# Altium schematic sheet controleSTM.SchDoc(controleSTM)
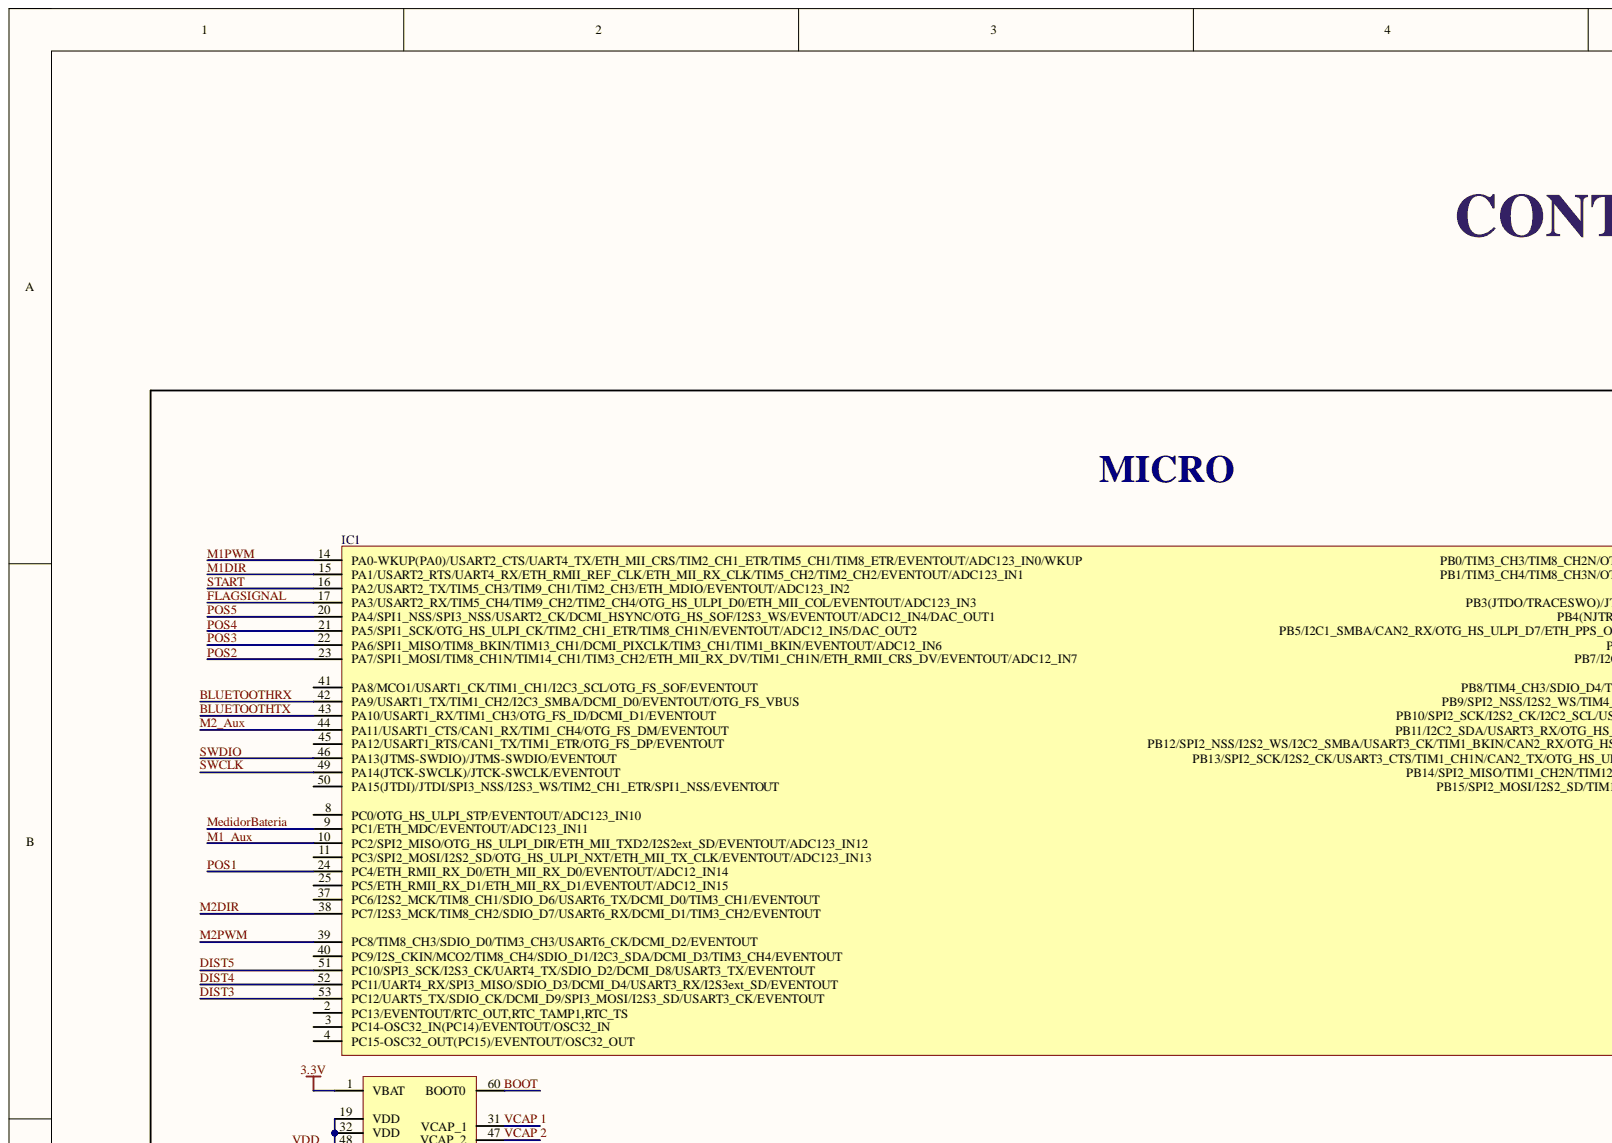
[diagram p0: controleSTM.SchDoc, top left]
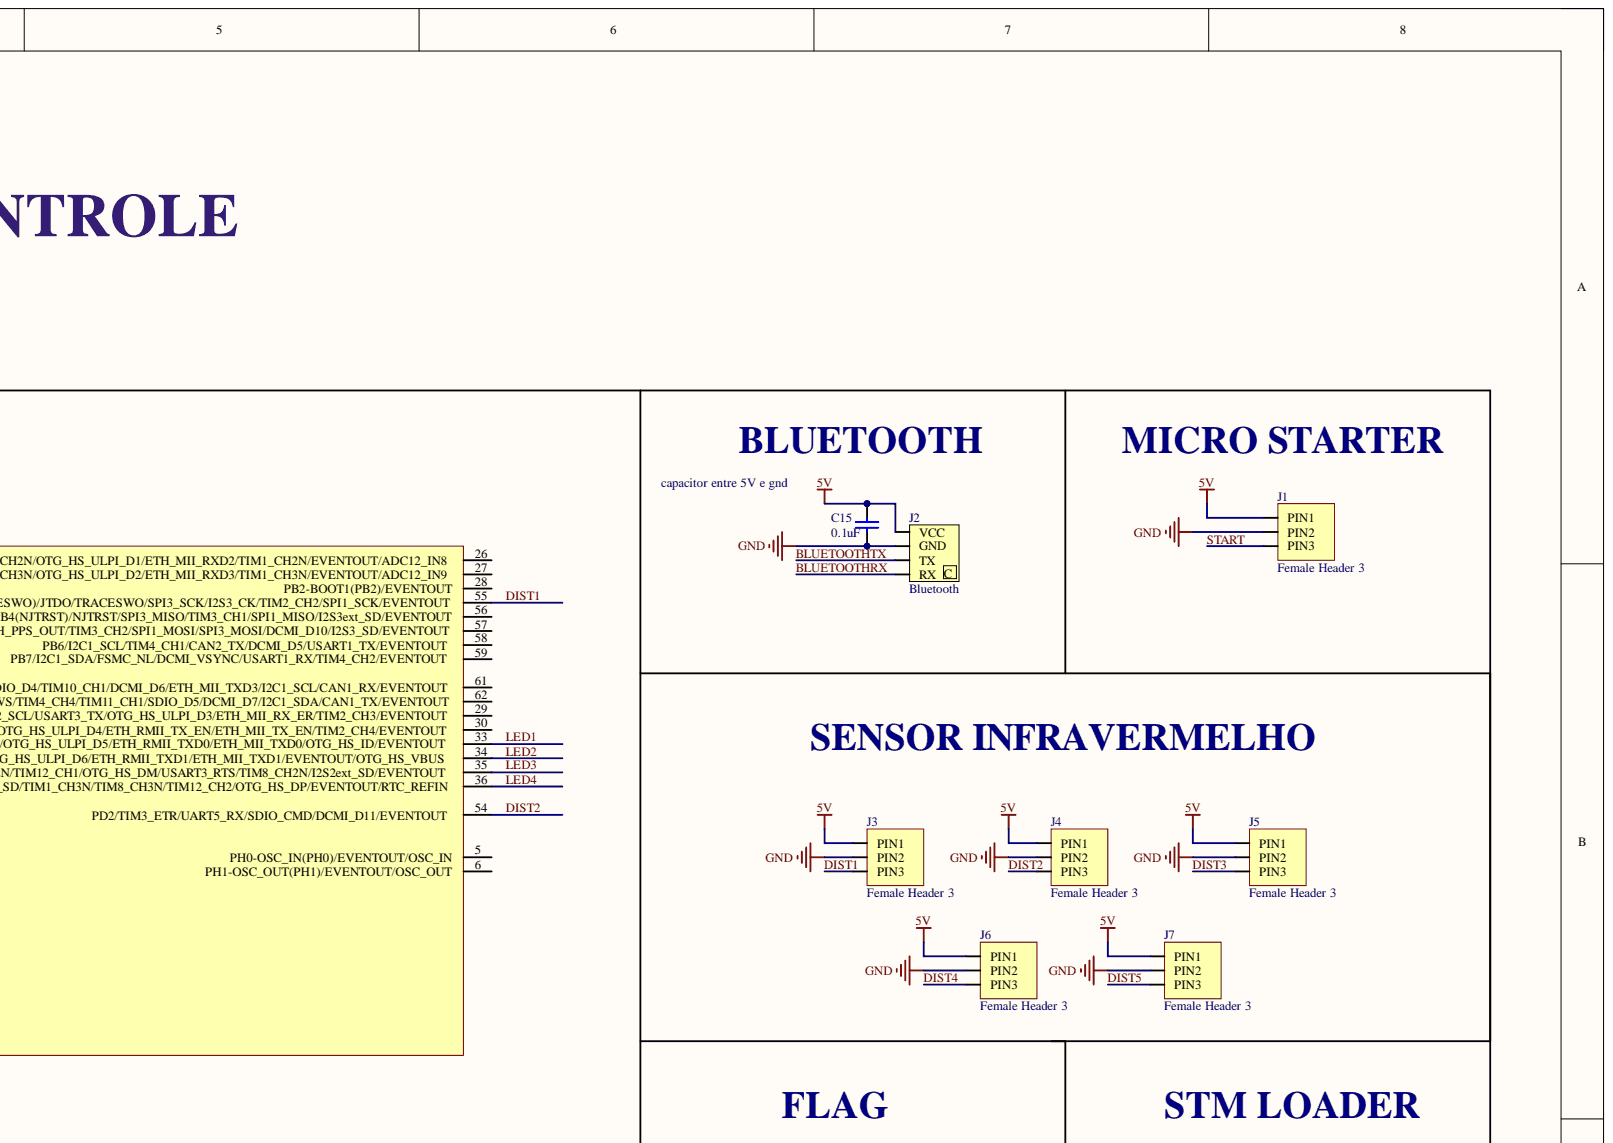
[diagram p1: controleSTM.SchDoc, top right]
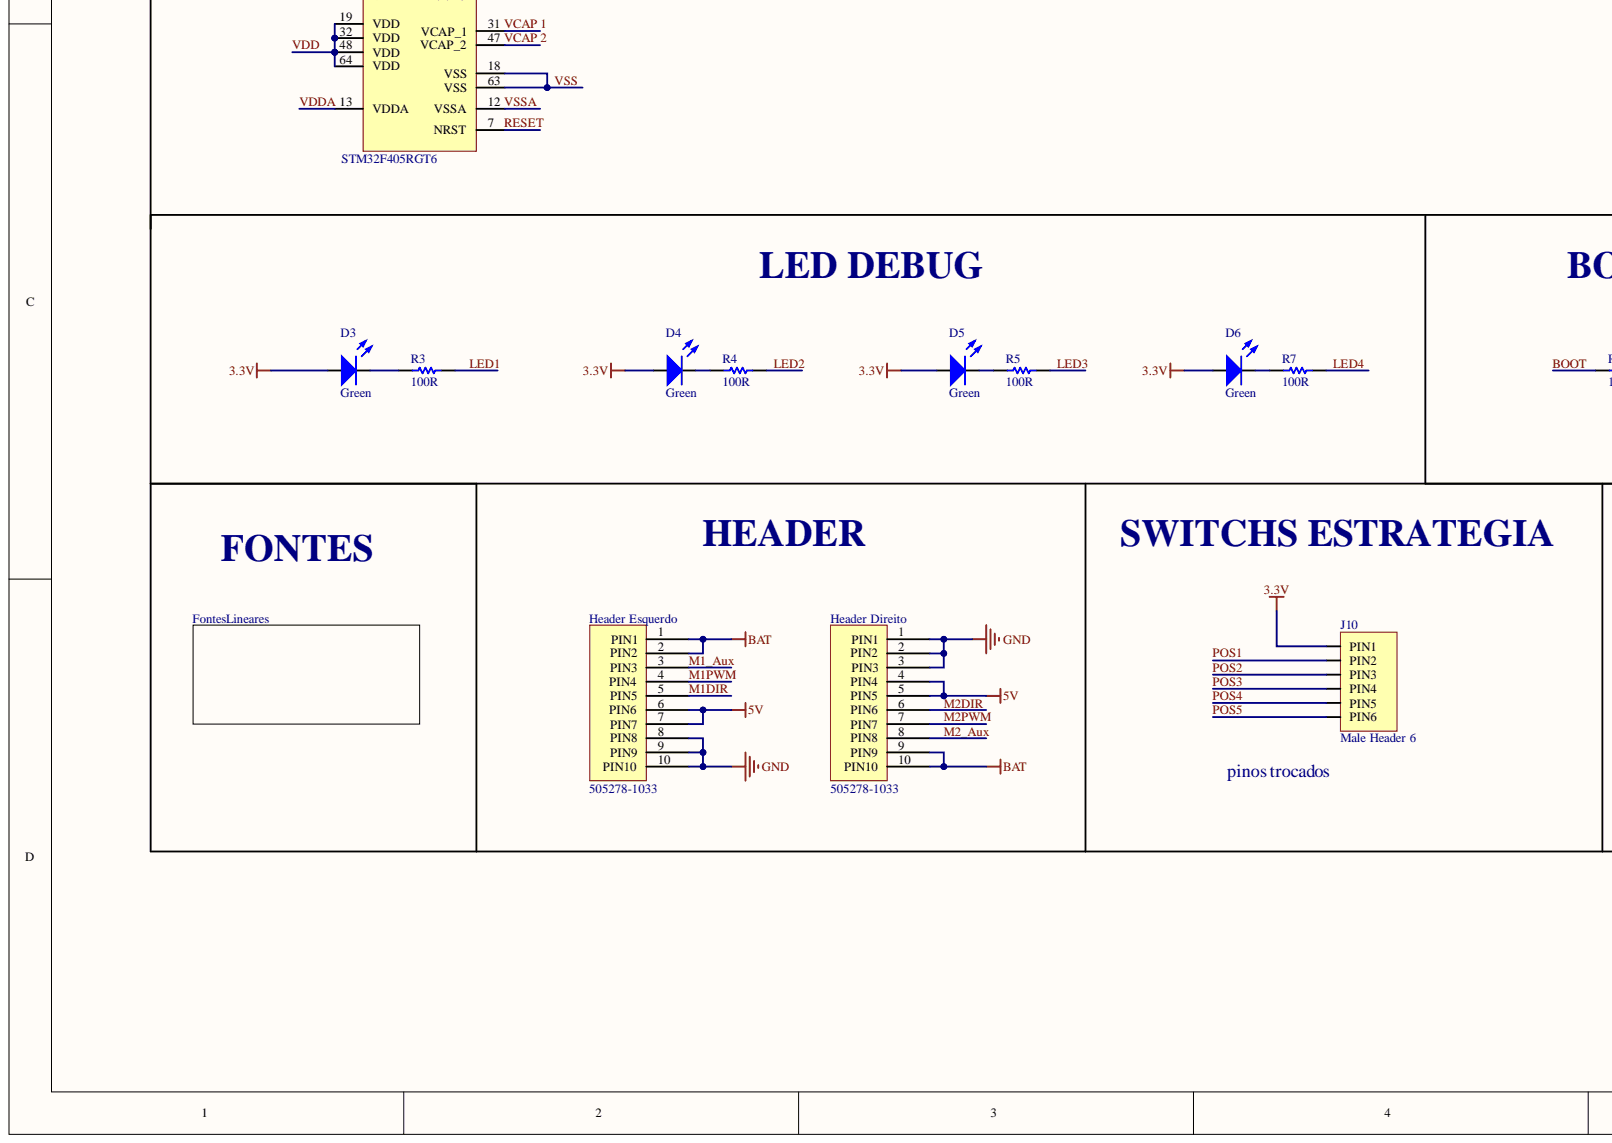
[diagram p2: controleSTM.SchDoc, bottom left]
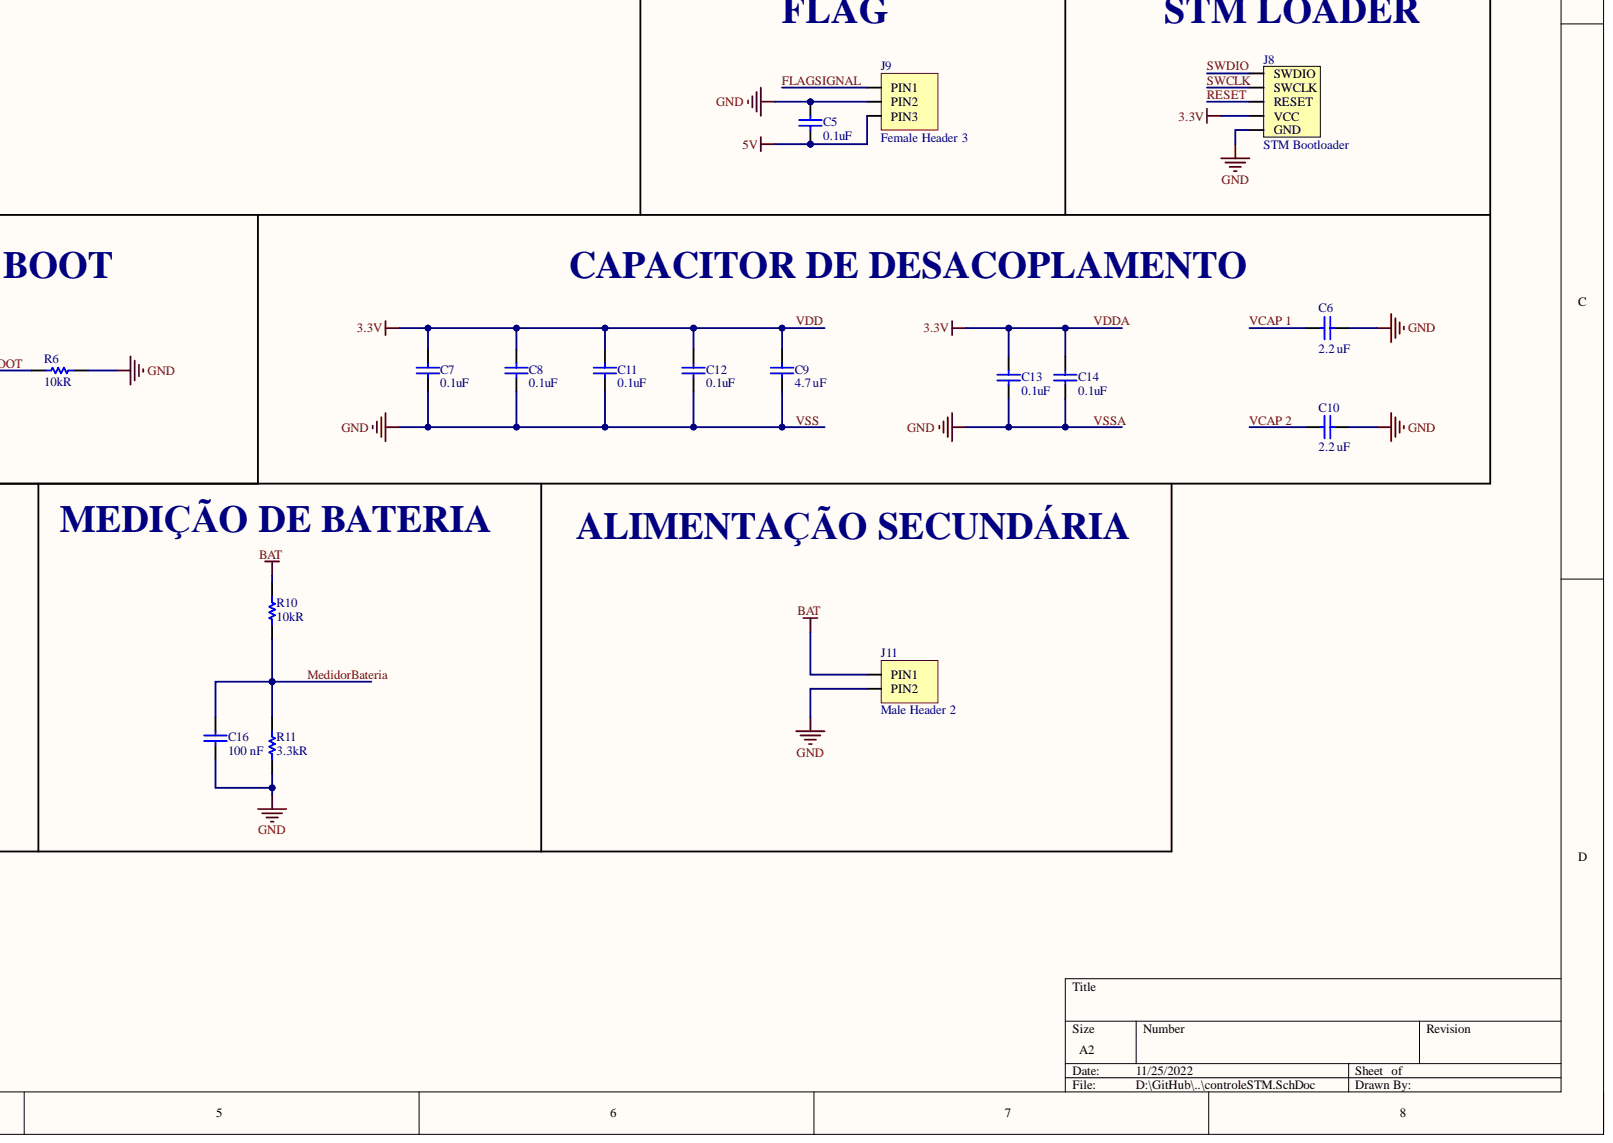
[diagram p3: controleSTM.SchDoc, bottom right]

## Parts
C5  0.1uF  [C0805]
C6  2.2 uF  [C0805]
C7  0.1uF  [C0805]
C8  0.1uF  [C0805]
C9  4.7 uF  [C0805]
C10  2.2 uF  [C0805]
C11  0.1uF  [C0805]
C12  0.1uF  [C0805]
C13  0.1uF  [C0805]
C14  0.1uF  [C0805]
C15  0.1uF  [C0805]
C16  100 nF  [C0805]
D3  Green  [LED_0603]
D4  Green  [LED_0603]
D5  Green  [LED_0603]
D6  Green  [LED_0603]
Header_Direito  505278-1033  [FPC_CON]
Header_Esquerdo  505278-1033  [FPC_CON]
IC1  STM32F405RGT6  [LQFP-64]
J1  Female Header 3  Library Ref: Header  [FEMALE HEADER 3]
J2  Bluetooth  [BLUETOOTH]
J3  Female Header 3  Library Ref: Header  [FEMALE HEADER 3]
J4  Female Header 3  Library Ref: Header  [FEMALE HEADER 3]
J5  Female Header 3  Library Ref: Header  [FEMALE HEADER 3]
J6  Female Header 3  Library Ref: Header  [FEMALE HEADER 3]
J7  Female Header 3  Library Ref: Header  [FEMALE HEADER 3]
J8  STM Bootloader  [STM BOOTLOADER]
J9  Female Header 3  Library Ref: Header  [FEMALE HEADER 3]
J10  Male Header 6  Library Ref: Header  [MALE HEADER 6]
J11  Male Header 2  Library Ref: Header  [MALE HEADER 2]
R3  100R  [RESISTOR_0805]
R4  100R  [RESISTOR_0805]
R5  100R  [RESISTOR_0805]
R6  10kR  [RESISTOR_0603]
R7  100R  [RESISTOR_0805]
R10  10kR  [RESISTOR_0603]
R11  3.3kR  [RESISTOR_0603]

## Nets
5V: C5.1 C15.2 Header_Direito.4(PIN4) Header_Direito.5(PIN5) Header_Esquerdo.6(PIN6) Header_Esquerdo.7(PIN7) J1.PIN1 J2.VCC J3.PIN1 J4.PIN1 J5.PIN1 J6.PIN1 J7.PIN1 J9.PIN3
BAT: Header_Direito.9(PIN9) Header_Direito.10(PIN10) Header_Esquerdo.1(PIN1) Header_Esquerdo.2(PIN2) J11.PIN1 R10.2
BLUETOOTHRX: IC1.42(PA9/USART1_TX/TIM1_CH2/I2C3_SMBA/DCMI_D0/EVENTOUT/OTG_FS_VBUS) J2.RX
BLUETOOTHTX: IC1.43(PA10/USART1_RX/TIM1_CH3/OTG_FS_ID/DCMI_D1/EVENTOUT) J2.TX
BOOT: IC1.60 R6.1
DIST1: IC1.55(PB3(JTDO/TRACESWO)/JTDO/TRACESWO/SPI3_SCK/I2S3_CK/TIM2_CH2/SPI1_SCK/EVENTOUT) J3.PIN3
DIST2: IC1.54(PD2/TIM3_ETR/UART5_RX/SDIO_CMD/DCMI_D11/EVENTOUT) J4.PIN3
DIST3: IC1.53(PC12/UART5_TX/SDIO_CK/DCMI_D9/SPI3_MOSI/I2S3_SD/USART3_CK/EVENTOUT) J5.PIN3
DIST4: IC1.52(PC11/UART4_RX/SPI3_MISO/SDIO_D3/DCMI_D4/USART3_RX/I2S3ext_SD/EVENTOUT) J6.PIN3
DIST5: IC1.51(PC10/SPI3_SCK/I2S3_CK/UART4_TX/SDIO_D2/DCMI_D8/USART3_TX/EVENTOUT) J7.PIN3
FLAGSIGNAL: IC1.17(PA3/USART2_RX/TIM5_CH4/TIM9_CH2/TIM2_CH4/OTG_HS_ULPI_D0/ETH_MII_COL/EVENTOUT/ADC123_IN3) J9.PIN1
LED1: IC1.33(PB12/SPI2_NSS/I2S2_WS/I2C2_SMBA/USART3_CK/TIM1_BKIN/CAN2_RX/OTG_HS_ULPI_D5/ETH_RMII_TXD0/ETH_MII_TXD0/OTG_HS_ID/EVENTOUT) R3.2
LED2: IC1.34(PB13/SPI2_SCK/I2S2_CK/USART3_CTS/TIM1_CH1N/CAN2_TX/OTG_HS_ULPI_D6/ETH_RMII_TXD1/ETH_MII_TXD1/EVENTOUT/OTG_HS_VBUS) R4.2
LED3: IC1.35(PB14/SPI2_MISO/TIM1_CH2N/TIM12_CH1/OTG_HS_DM/USART3_RTS/TIM8_CH2N/I2S2ext_SD/EVENTOUT) R5.2
LED4: IC1.36(PB15/SPI2_MOSI/I2S2_SD/TIM1_CH3N/TIM8_CH3N/TIM12_CH2/OTG_HS_DP/EVENTOUT/RTC_REFIN) R7.2
M1_Aux: Header_Esquerdo.3(PIN3) IC1.10(PC2/SPI2_MISO/OTG_HS_ULPI_DIR/ETH_MII_TXD2/I2S2ext_SD/EVENTOUT/ADC123_IN12)
M1DIR: Header_Esquerdo.5(PIN5) IC1.15(PA1/USART2_RTS/UART4_RX/ETH_RMII_REF_CLK/ETH_MII_RX_CLK/TIM5_CH2/TIM2_CH2/EVENTOUT/ADC123_IN1)
M1PWM: Header_Esquerdo.4(PIN4) IC1.14(PA0-WKUP(PA0)/USART2_CTS/UART4_TX/ETH_MII_CRS/TIM2_CH1_ETR/TIM5_CH1/TIM8_ETR/EVENTOUT/ADC123_IN0/WKUP)
M2_Aux: Header_Direito.8(PIN8) IC1.44(PA11/USART1_CTS/CAN1_RX/TIM1_CH4/OTG_FS_DM/EVENTOUT)
M2DIR: Header_Direito.6(PIN6) IC1.38(PC7/I2S3_MCK/TIM8_CH2/SDIO_D7/USART6_RX/DCMI_D1/TIM3_CH2/EVENTOUT)
M2PWM: Header_Direito.7(PIN7) IC1.39(PC8/TIM8_CH3/SDIO_D0/TIM3_CH3/USART6_CK/DCMI_D2/EVENTOUT)
MedidorBateria: C16.2 IC1.9(PC1/ETH_MDC/EVENTOUT/ADC123_IN11) R10.1 R11.2
POS1: IC1.24(PC4/ETH_RMII_RX_D0/ETH_MII_RX_D0/EVENTOUT/ADC12_IN14) J10.PIN2
POS2: IC1.23(PA7/SPI1_MOSI/TIM8_CH1N/TIM14_CH1/TIM3_CH2/ETH_MII_RX_DV/TIM1_CH1N/ETH_RMII_CRS_DV/EVENTOUT/ADC12_IN7) J10.PIN3
POS3: IC1.22(PA6/SPI1_MISO/TIM8_BKIN/TIM13_CH1/DCMI_PIXCLK/TIM3_CH1/TIM1_BKIN/EVENTOUT/ADC12_IN6) J10.PIN4
POS4: IC1.21(PA5/SPI1_SCK/OTG_HS_ULPI_CK/TIM2_CH1_ETR/TIM8_CH1N/EVENTOUT/ADC12_IN5/DAC_OUT2) J10.PIN5
POS5: IC1.20(PA4/SPI1_NSS/SPI3_NSS/USART2_CK/DCMI_HSYNC/OTG_HS_SOF/I2S3_WS/EVENTOUT/ADC12_IN4/DAC_OUT1) J10.PIN6
RESET: IC1.7 J8.RESET
START: IC1.16(PA2/USART2_TX/TIM5_CH3/TIM9_CH1/TIM2_CH3/ETH_MDIO/EVENTOUT/ADC123_IN2) J1.PIN3
SWCLK: IC1.49(PA14(JTCK-SWCLK)/JTCK-SWCLK/EVENTOUT) J8.CLK(SWCLK)
SWDIO: IC1.46(PA13(JTMS-SWDIO)/JTMS-SWDIO/EVENTOUT) J8.DIO(SWDIO)
VCAP 1: C6.1 IC1.31
VCAP 2: C10.1 IC1.47
VDD: C7.2 C8.2 C9.2 C11.2 C12.2 C13.2 C14.2 D3.A D4.A D5.A D6.A IC1.1(VBAT) IC1.13(VDDA) IC1.19(VDD) IC1.32(VDD) IC1.48(VDD) IC1.64(VDD) J8.VCC J10.PIN1
VSS: C5.2 C6.2 C7.1 C8.1 C9.1 C10.2 C11.1 C12.1 C13.1 C14.1 C15.1 C16.1 Header_Direito.1(PIN1) Header_Direito.2(PIN2) Header_Direito.3(PIN3) Header_Esquerdo.8(PIN8) Header_Esquerdo.9(PIN9) Header_Esquerdo.10(PIN10) IC1.12 IC1.18 IC1.63 J1.PIN2 J2.GND J3.PIN2 J4.PIN2 J5.PIN2 J6.PIN2 J7.PIN2 J8.GND J9.PIN2 J11.PIN2 R6.2 R11.1
NetD3_C: D3.C R3.1
NetD4_C: D4.C R4.1
NetD5_C: D5.C R5.1
NetD6_C: D6.C R7.1

Not connected: IC1 2(PC13/EVENTOUT/RTC_OUT,RTC_TAMP1,RTC_TS) 3(PC14-OSC32_IN(PC14)/EVENTOUT/OSC32_IN) 4(PC15-OSC32_OUT(PC15)/EVENTOUT/OSC32_OUT) 5(PH0-OSC_IN(PH0)/EVENTOUT/OSC_IN) 6(PH1-OSC_OUT(PH1)/EVENTOUT/OSC_OUT) 8(PC0/OTG_HS_ULPI_STP/EVENTOUT/ADC123_IN10) 11(PC3/SPI2_MOSI/I2S2_SD/OTG_HS_ULPI_NXT/ETH_MII_TX_CLK/EVENTOUT/ADC123_IN13) 25(PC5/ETH_RMII_RX_D1/ETH_MII_RX_D1/EVENTOUT/ADC12_IN15) 26(PB0/TIM3_CH3/TIM8_CH2N/OTG_HS_ULPI_D1/ETH_MII_RXD2/TIM1_CH2N/EVENTOUT/ADC12_IN8) 27(PB1/TIM3_CH4/TIM8_CH3N/OTG_HS_ULPI_D2/ETH_MII_RXD3/TIM1_CH3N/EVENTOUT/ADC12_IN9) 28(PB2-BOOT1(PB2)/EVENTOUT) 29(PB10/SPI2_SCK/I2S2_CK/I2C2_SCL/USART3_TX/OTG_HS_ULPI_D3/ETH_MII_RX_ER/TIM2_CH3/EVENTOUT) 30(PB11/I2C2_SDA/USART3_RX/OTG_HS_ULPI_D4/ETH_RMII_TX_EN/ETH_MII_TX_EN/TIM2_CH4/EVENTOUT) 37(PC6/I2S2_MCK/TIM8_CH1/SDIO_D6/USART6_TX/DCMI_D0/TIM3_CH1/EVENTOUT) 40(PC9/I2S_CKIN/MCO2/TIM8_CH4/SDIO_D1/I2C3_SDA/DCMI_D3/TIM3_CH4/EVENTOUT) 41(PA8/MCO1/USART1_CK/TIM1_CH1/I2C3_SCL/OTG_FS_SOF/EVENTOUT) 45(PA12/USART1_RTS/CAN1_TX/TIM1_ETR/OTG_FS_DP/EVENTOUT) 50(PA15(JTDI)/JTDI/SPI3_NSS/I2S3_WS/TIM2_CH1_ETR/SPI1_NSS/EVENTOUT) 56(PB4(NJTRST)/NJTRST/SPI3_MISO/TIM3_CH1/SPI1_MISO/I2S3ext_SD/EVENTOUT) 57(PB5/I2C1_SMBA/CAN2_RX/OTG_HS_ULPI_D7/ETH_PPS_OUT/TIM3_CH2/SPI1_MOSI/SPI3_MOSI/DCMI_D10/I2S3_SD/EVENTOUT) 58(PB6/I2C1_SCL/TIM4_CH1/CAN2_TX/DCMI_D5/USART1_TX/EVENTOUT) 59(PB7/I2C1_SDA/FSMC_NL/DCMI_VSYNC/USART1_RX/TIM4_CH2/EVENTOUT) 61(PB8/TIM4_CH3/SDIO_D4/TIM10_CH1/DCMI_D6/ETH_MII_TXD3/I2C1_SCL/CAN1_RX/EVENTOUT) 62(PB9/SPI2_NSS/I2S2_WS/TIM4_CH4/TIM11_CH1/SDIO_D5/DCMI_D7/I2C1_SDA/CAN1_TX/EVENTOUT)

## Library data of the parts
C5 C7 C8 C11-C15 = C0805C104M3RACTU: CAP CER 0.1uF 25V X7R 0805; Manufacturer: Kemet; Library Control - Checked Footprint: No; Library Control - Checked Schematic: Yes; Library Control - Person that Checked Schematic: Guilherme; Library Control - Person that did the Last Update Schematic: Marcos; Tolerance: 20%; Voltage - Rated: 25 VDC; Category: Ceramic Capacitor; Component Kind: Standard; ComponentLink1Description: Datasheet; ComponentLink1URL: https://br.mouser.com/datasheet/2/212/KEM_C1002_X7R_SMD-1102033.pdf; ComponentLink2Description: Mouser Link; ComponentLink2URL: https://br.mouser.com/ProductDetail/KEMET/C0805C104M3RACTU?qs=jbCUrP3sAgSIdMuvv9W4Pg%3D%3D; Dieletric: X7R; ESR: -; Library Control - Last Update: 26/06/2021 20:52; Library Ref: Capacitor; Operating Temperature: -55 C ~ 125 C; Package / Case: 0805(2012 mestric); Part Number: C0805C104M3RACTU; Price: 1=0,13 10=0,064 100=0,037 1000=0,025 (USD); Size / Dimension: 1.25 mm W x 2 mm L x 0.78 mm H; Supplier 1: Mouser; Supplier Part Number 1: 80-C0805C104M3R; Value: 0.1uF; Library Name: Uai!Tecas.IntLib
C6 C10 = GCM21BR71C225KA64L: CAP CER 2.2uF 16V X7R 0805; Manufacturer: MURATA ELECTRONICS; Library Control - Checked Footprint: Yes; Library Control - Person that Checked Footprint: Cage; Tolerance: 10%; Voltage - Rated: 16 VDC; Category: Ceramic capacitor; Component Kind: Standard; ComponentLink1Description: Datasheet; ComponentLink1URL: https://br.mouser.com/ProductDetail/Murata-Electronics/GCM21BR71C225KA64L?qs=aEuGZpxfbxV0E5XH%252BwYupA%3D%3D; ComponentLink2Description: Mouser Link; ComponentLink2URL: https://br.mouser.com/ProductDetail/Murata-Electronics/GCM21BR71C225KA64L?qs=aEuGZpxfbxV0E5XH%252BwYupA%3D%3D; Dieletric: X7R; ESR: -; Library Control - Checked Schematic: Yes; Library Control - Last Update: 25/08/22; Library Ref: Capacitor; Operating Temperature: -55°C ~125ºC; Package / Case: 0805(2012 Metric); Part Number: GCM21BR71C225KA64L; Price: 1=0,41 10=0,283 100=0,169 1000=0,107 (USD); Size / Dimension: 2 mm L  x 1.25 mm W x 1.25 mm H; Supplier 1: Mouser; Supplier Part Number 1: 81-GCM21BR71C225KA4L; Value: 2.2 uF; Library Control - Person that Checked Schematic: Cage; Library Control - Person that did the Last Update Footprint: Cage; Library Control - Person that did the Last Update Schematic: Cage; Library Name: Uai!Tecas.IntLib
C9 = GRM219R61E475KA73D: CAP CER 4.7uF 25V X5R 0805; Manufacturer: MURATA ELECTRONICS; Library Control - Checked Footprint: Yes; Library Control - Person that Checked Footprint: Cage; Tolerance: 10%; Voltage - Rated: 25 VDC; Category: Ceramic Capacitors; Component Kind: Standard; ComponentLink1Description: Datasheet; ComponentLink1URL: https://br.mouser.com/datasheet/2/281/1/GRM219R61E475KA73_01A-1986363.pdf; ComponentLink2Description: Mouser Link; ComponentLink2URL: https://br.mouser.com/ProductDetail/Murata-Electronics/GRM219R61E475KA73D?qs=%2Fha2pyFadui1540apPxqrcvNL2Od6%2FE9q78FE8iyERiL9ZO4NhnlONCgyc%2FC2rEQ; Dieletric: X5R; ESR: -; Library Control - Checked Schematic: Yes; Library Control - Last Update: 25/08/22; Library Ref: Capacitor; Operating Temperature: -55°C ~ 85°C; Package / Case: 0805(2012 metric); Part Number: GRM219R61E475KA73D; Price: 1=0,28 10=0,193 100=0,098 1000=0,059 (USD); Size / Dimension: 2 mm L x 1.25 mm W x 0.85 mm H; Supplier 1: Mouser; Supplier Part Number 1: 81-GRM219R61E475KA73D; Value: 4.7 uF; Library Control - Person that Checked Schematic: Cage; Library Control - Person that did the Last Update Footprint: Cage; Library Control - Person that did the Last Update Schematic: Cage; Library Name: Uai!Tecas.IntLib
C16 = 885012207128: CAP CER 100 nF 100V X7R 0805; Manufacturer: Wurth Elektronik; Library Control - Checked Footprint: Yes; Tolerance: 10%; Voltage - Rated: 100 VDC; Category: Ceramic capacitor; Component Kind: Standard; ComponentLink1Description: Datasheet; ComponentLink1URL: https://br.mouser.com/datasheet/2/445/885012207128-1727772.pdf; ComponentLink2Description: Mouser Link; ComponentLink2URL: https://br.mouser.com/ProductDetail/Wurth-Elektronik/885012207128?qs=MLItCLRbWsys1TnbPEYt%252BQ%3D%3D; Dieletric: X7R; ESR: -; Library Control - Checked Schematic: Yes; Library Ref: Capacitor; Operating Temperature: -55°C ~125ºC; Package / Case: 0805(2012 Metric); Part Number: 885012207128; Price: 1=0,41 10=0,283 100=0,169 1000=0,107 (USD); Size / Dimension: 2 mm L  x 1.25 mm W x 1.25 mm H; Supplier 1: Mouser; Supplier Part Number 1: 710-885012207128; Value: 100 nF; Library Name: Uai!Tecas.IntLib
D3-D6 = 150060VS75000: LED 72mW GREEN 40MCD 0603; Manufacturer: Wurth Elektronik; Current - Forward (If): 20 mA; Library Control - Last Project that Used: Diodo/Led; Library Control - Person that Checked Footprint: Stéfany (Ster); Library Control - Person that did the Last Update Schematic: Marcos; Max Current Rating: 100mA; Reverse Voltage: 5V; Viewing Angle: 140°; Voltage - Forward (Vf): 2 V; Wavelength: 570nm; Category: Standard LEDs - SMD; Color: Green; Component Kind: Standard; ComponentLink1Description: Mouser Link; ComponentLink1URL: https://br.mouser.com/ProductDetail/Wurth-Elektronik/150060VS75000?qs=%2Fha2pyFaduhuT%2FKGSijZP0vTyJZ9U993KfsBQSxqATYhY9jnc2c09tXoMoJ3wn4L; ComponentLink2Description: Datasheet Link; ComponentLink2URL: https://br.mouser.com/datasheet/2/445/150060VS75000-1714627.pdf; Library Control - Checked Footprint: 25/07/2021; Library Control - Checked Schematic: 25/07/2021; Library Control - Last Update: 09/09/2021 16:12; Library Ref: LED; Luminous Intensity: 40 mcd; Max Power Dissipation: 72mW; Operating Temperature: -40~85 °C; Package / Case: 0603; Part Number: 150060VS75000; Price: 1 = 0.14, 50 = 0.133, 100 = 0.114 (USD); Supplier 1: Mouser; Supplier Part Number 1: 710-150060VS75000; Library Control - Person that Checked Schematic: Stéfany (Ster); Library Control - Person that did the Last Update Footprint: Stéfany (Ster); Library Name: Uai!Tecas.IntLib
Header_Direito Header_Esquerdo = 505278-1033_BODY: CONN FFC/FPC HORZ 10POS 0.50MM; Manufacturer: Molex; Current Rating (Amps): 500mA; Library Control - Checked Footprint: Yes; Library Control - Last Project that Used: Follow-Final; Library Control - Person that Checked Footprint: Lucas; Library Control - Person that did the Last Update Footprint: Mateus(Dimas); Number of Positions: 10; Category: Connectors; Component Kind: Standard; ComponentLink1Description: Datasheet; ComponentLink1URL: https://br.mouser.com/datasheet/2/276/2/5052781033_FFC_FPC_CONNECTORS-772019.pdf; ComponentLink2Description: Mouser Link; ComponentLink2URL: https://br.mouser.com/ProductDetail/Molex/505278-1033?qs=c8NFF48pVsDStgrQCBOSTg%3D%3D; Contact Length - Mating: 0.50mm; Contact Material: Tin; Contact Type: FFC/FPC; Insulation Material: Liquid Crystal Polymer; Library Control - Checked Schematic: Yes; Library Control - Last Update: 24/04/2021 00:25; Library Ref: Connectors; Mounting Type: Right Angle; Number of Rows: 1; Operating Temperature: -40°C ~ 85°C; Part Number: 505278-1033; Pitch: 0.50mm; Price: 1=0,51000, 10=0,42900, 100=0,33400 (USD); Supplier 1: Mouser; Supplier Part Number 1: 538-505278-1033; Termination: SMD; Library Control - Person that Checked Schematic: Lucas; Library Control - Person that did the Last Update Schematic: Mateus(Dimas); Library Name: Uai!Tecas.IntLib
IC1 = STM32F405RGT6: IC MCU 32-BIT 192kB FLASH ARM Cortex-M4 168MHz  LQFP-64; Manufacturer: STM; Core Processor: ARM Cortex M0+; Library Control - Person that did the Last Update Footprint: Marcos; Number of ADC Channels: 16; Number of I2C Channels: 3; Number of I/Os: 51; Number of Timers/Counters: 17; Number of UART/USART Channels: 4; Oscillator Type: Internal; Peripherals: CAN, I2C, SDIO, I2S / SPI, UART / USART, USB; Program Memory Size: 1024kB; Voltage - Supply (Vcc/Vdd): 1.8 V to 3.6 V; Category: ARM Microcontrollers - MCU; Component Kind: Standard; ComponentLink1Description: Mouser Link; ComponentLink1URL: https://www.mouser.com/ProductDetail/STMicroelectronics/STM32F405RGT6?qs=Z8%252BeY1k3TIKgj7QWsYGpQw%3D%3D; ComponentLink2Description: Datasheet Link; ComponentLink2URL: https://www.mouser.com/datasheet/2/389/dm00037051-1797298.pdf; Connectivity: -; Library Control - Last Update: 22/08/21 15:09; Library Ref: Microcrontrollers; Number of Ethernet Channels: -; Number of SPI Channels: 3; Operating Temperature: -40°C to 85°C; Package/Case: LQFP-64; Part Number: STM32F405RGT6; Price: 1 = 12,95, 10 = 11,91,  100 = 11,42, 500 = 8,95 (USD); Program Memory Type: Flash; RAM Size: 192k B; Series: STM32F405RG; Speed: 168MHz; Supplier 1: Mouser; Supplier Part Number 1: 511-STM32F405RGT6; Library Control - Person that did the Last Update Schematic: Marcos; Library Name: Uai!Tecas.IntLib
J1 J3-J7 J9 = Female Header 3: Number of Contacts: 3; System: Connector; Component Kind: Standard; Description: Female Header 3; Library Name: Uai!Tecas.IntLib
J2 = Barramento Bluetooth: BARRAMENTO BLUETOOTH HC-06; Bluetooth Mode: Slave Only; Frequency: 2,4 GHz; Max Range: 10m; Maximum Serial Transmission Rate: 9600 bps; Modulation: GFSK (Gaussian Frequency Shift Keying); Number of Contacts: 4; Operating Voltage: 3,6 / 6 (V); Recomended Operating Configuration: 5V @ 50mA; Component Kind: Standard; Library Ref: Header; Library Name: Uai!Tecas.IntLib
J8 = Barramento de Gravação STM: BARRAMENTO DE GRAVAÇÃO; Number of Contacts: 5; Component Kind: Standard; Library Ref: Header; Library Name: Uai!Tecas.IntLib
J10 = Male Header 6: Library Control - Checked Footprint: Yes; Library Control - Person that Checked Footprint: Cage; Number of Contacts: 6; System: Connector; Component Kind: Standard; Description: Male Header 6; Library Control - Checked Schematic: Yes; Library Control - Last Update: 02/10/2022; Library Control - Person that Checked Schematic: Cage; Library Control - Person that did the Last Update Schematic: Cage; Library Control - Persont that did the Last Update Footprint: Cage; Library Name: Uai!Tecas.IntLib
J11 = Male Header 2: Library Control - Person that did the Last Update Schematic: Marcos; Number of Contacts: 2; System: Connector; Component Kind: Standard; Description: Male Header 2; Library Control - Persont that did the Last Update Footprint: Marcos; Library Name: Uai!Tecas.IntLib
R3-R5 R7 = CRCW0805100RFKEA: RES SMD 100 OHM 1% 1/8W 0805; Manufacturer: Vishay / Dale; Library Control - Checked Footprint: No; Library Control - Checked Schematic: Yes; Library Control - Person that Checked Schematic: Marcos; Library Control - Person that did the Last Update Footprint: Dalarme; Power Rating: 125 mW (1/8 W); Temperature Coefficient: 100 PPM / C; Voltage Rating: 150 V; Category: Thick Film Resistors - SMD; Component Kind: Standard; ComponentLink1Description: Mouser Link; ComponentLink1URL: https://www.mouser.com/ProductDetail/Vishay-Dale/CRCW0805100RFKEA?qs=sGAEpiMZZMu61qfTUdNhG2DpbjADlD3GxPcNG3UnvYk%3D; ComponentLink2Description: Datasheet Link; ComponentLink2URL: https://www.mouser.com/datasheet/2/427/dcrcwe3-1762152.pdf; Library Control - Last Update: 20/06/21 16:56; Library Ref: Resistor; Operating Temperature: - 55ºC ~ 155ºC; Package / Case: 0805 (2012 Metric); Part Number: CRCW0805100RFKEA; Price: 1=0,18, 10=0,065, 100=0,027, 1000=0,012 (USD); Resistor Type: Fixo; Size / Dimension: 2 mm L x  1.25 mm W x  0.45 mm H; Supplier 1: Mouser; Supplier Part Number 1: 71-CRCW0805-100-E3; Tolerance: 1 %; Value: 100R; Library Control - Person that did the Last Update Schematic: Marcos; Library Name: Uai!Tecas.IntLib
R6 R10 = RC0603JR-0710KL: RES SMD 10K OHM 5% 1/10W 0603; Manufacturer: Yageo; Library Control - Checked Footprint: No; Library Control - Checked Schematic: Yes; Library Control - Person that Checked Schematic: Marcos; Library Control - Person that did the Last Update Footprint: Dalarme; Power Rating: 100 mW (1/10 W); Temperature Coefficient: 100 PPM / C; Voltage Rating: 75 V; Category: Thick Film Resistors - SMD; Component Kind: Standard; ComponentLink1Description: Mouser Link; ComponentLink1URL: https://br.mouser.com/ProductDetail/Yageo/RC0603JR-0710KL?qs=ksOUTF%2FWcd8D6T22BJkQ7g%3D%3D; ComponentLink2Description: Datsheet Link; ComponentLink2URL: https://br.mouser.com/datasheet/2/447/Yageo_03_18_2021_PYu_RC_Group_51_RoHS_L_11-2199992.pdf; Library Control - Last Update: 21/06/21 14:15; Library Ref: Resistor; Operating Temperature: - 55ºC ~ 155ºC; Package / Case: 0603 (1608 Metric); Part Number: RC0603JR-0710KL; Price: 1=0,10, 10=0,015, 100=0,005 1000=0,0040 (USD); Resistor Type: Fixo; Size / Dimension: 1.6 mm L x  0.8 mm W x  0.45 mm H; Supplier 1: Mouser; Supplier Part Number 1: 603-RC0603JR-0710KL; Tolerance: 5 %; Value: 10kR; Library Control - Person that did the Last Update Schematic: Marcos; Library Name: Uai!Tecas.IntLib
R11 = CRGCQ0603F3K3: RES SMD 3.3K OHM 1% 1/10W 0603; Manufacturer: TE Connectivity / Holsworthy; Library Control - Checked Footprint: Yes; Library Control - Person that Checked Footprint: Nikaum; Library Control - Person that Checked Schematic: Guilherme; Library Control - Person that did the Last Update Footprint: Dalarme; Power Rating: 100 mW (1/10 W); Temperature Coefficient: 100 PPM / C; Voltage Rating: 75 V; Category: Thick Film Resistors; Component Kind: Standard; ComponentLink1Description: Mouser Link; ComponentLink1URL: https://br.mouser.com/ProductDetail/TE-Connectivity-Holsworthy/CRGCQ0603F3K3?qs=sGAEpiMZZMvdGkrng054t7z4BkURc4Lz7mzhBruKq8fwVJ16OlxZFQ%3D%3D; ComponentLink2Description: Datasheet; ComponentLink2URL: https://br.mouser.com/datasheet/2/418/4/NG_DS_1773204-3_1-1358553.pdf; Library Control - Checked Schematic: Yes; Library Control - Last Update: 27/06/2021 20:27; Library Ref: Resistor; Operating Temperature: - 55ºC ~ 125ºC; Package / Case: 0603 (1608 Metric); Part Number: CRGCQ0603F3K3; Price: 1=0,10, 10=0,029, 100=0,011 (USD); Resistor Type: Fixed; Size / Dimension: 1.6 mm L x  0.8 mm W x  0.45 mm H; Supplier 1: Mouser; Supplier Part Number 1: 279-CRGCQ0603F3K3; Tolerance: 1 %; Value: 3.3kR; Library Control - Person that did the Last Update Schematic: Dalarme; Library Name: Uai!Tecas.IntLib
